# Altium schematic sheet POWER_12OUT_CH2 不焊接.SchDoc
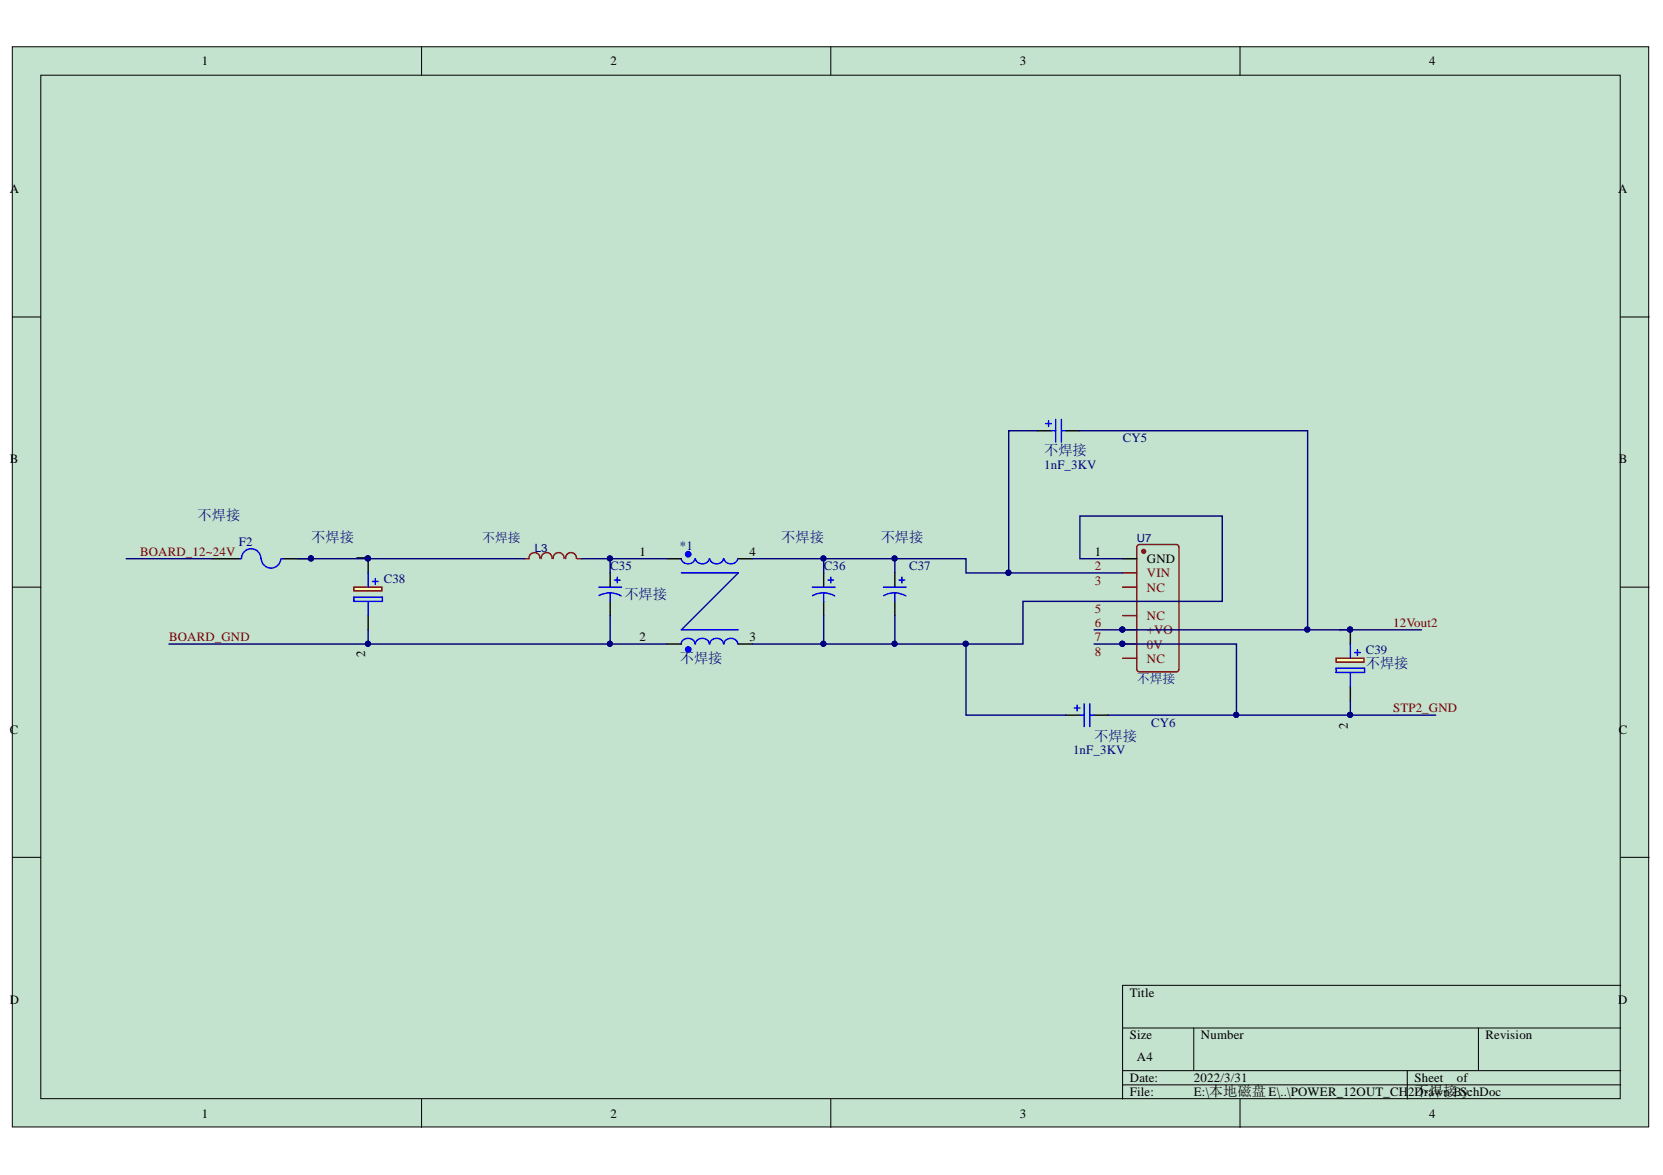
[diagram p0: POWER_12OUT_CH2 不焊接.SchDoc]

## Parts
*1  不焊接  [FL2D-XX-XXX]
C35  不焊接  [C1210_N]
C36  不焊接  [C1210_N]
C37  不焊接  [EEE0JA152UAP]
C38  不焊接  [CAP12.5*25]
C39  不焊接  [CAPPRD200W55D500H1200]
CY5  不焊接  value 1nF_3KV  [CAP1808]
CY6  不焊接  value 1nF_3KV  [CAP1808]
F2  不焊接  [1206_FUSE]
L3  不焊接  [IND-SMD_L5.2-W5.2_MS0530-XX]
U7  不焊接  [PWRM-TH_UWFXXXXS-3WR3]

## Nets
12VOUT2: C39.1 CY5.2 U7.6(+VO)
BOARD_12~24V: F2.1
BOARD_GND: *1.2 C35.2 C38.2
STP2_GND: C39.2 CY6.2 U7.7(0V)
Net*1_1: *1.1 C35.1 L3.2
Net*1_3: *1.3 C36.2 C37.2 CY6.1 U7.1(GND)
Net*1_4: *1.4 C36.1 C37.1 CY5.1 U7.2(VIN)
NetC38_1: C38.1 F2.2 L3.1

Not connected: U7 3(NC) 5(NC) 8(NC)

## Library data of the parts
*1 = FL2D: Component Kind: Standard; Library Name: Schlib1.SchLib
C35-C37 = Cap Pol2: Polarized Capacitor (Axial); Value: 10uF; Component Kind: Standard; Library Name: Miscellaneous Devices.IntLib; Published: 8-Jun-2000; Revision: July-2002: Re-released for DXP Platform.; Signal Integrity: Cap Pol; Simulation: CAP; Publisher: Altium Limited
C38 = ECA-1HHG102: Capacitor Polarised; Manufacturer_Name: Panasonic; Component Kind: Standard; Datasheet Link: https://componentsearchengine.com//ECA-1HHG102.pdf; Height: 27mm; Manufacturer_Part_Number: ECA-1HHG102; Mouser2 Part Number: 667-ECA-1HHG102; Mouser2 Price/Stock: https://www.mouser.com/Search/Refine.aspx?Keyword=667-ECA-1HHG102
C39 = ECA-1HHG220I: Capacitor Polarised; Manufacturer_Name: Panasonic; Component Kind: Standard; Datasheet Link: http://industrial.panasonic.com/cdbs/www-data/pdf/RDF0000/ABA0000C1215.pdf; Height: 12mm; Manufacturer_Part_Number: ECA-1HHG220I
CY5 CY6 = Cap Pol2: Polarized Capacitor (Axial); Component Kind: Standard; LatestRevisionDate: 17-Jul-2002; LatestRevisionNote: Re-released for DXP Platform.; Library Name: Miscellaneous Devices.IntLib; PackageDescription: Polarized Capacitor; 2 Leads; PackageReference: POLAR0.8; Published: 8-Jun-2000; Signal Integrity: Cap Pol; Simulation: CAP; Publisher: Altium Limited
F2 = Fuse 2: Fuse; Component Kind: Standard; LatestRevisionDate: 17-Jul-2002; LatestRevisionNote: Re-released for DXP Platform.; PackageReference: PIN-W2/E2.8; Published: 8-Jun-2000; Simulation: FUSE; Library Name: jinrylib.IntLib; Publisher: Altium Limited
L3 = 4.7uH: BOM_Manufacturer: COILMX; BOM_Manufacturer Part: MS0530-4R7M; Contributor: LCSC; nameAlias: Inductance; BOM_JLCPCB Part Class: Extended Part; BOM_Supplier: LCSC; BOM_Supplier Part: C380165; Component Kind: Standard; Library Name: Schlib1.SchLib; spicePre: L; spiceSymbolName: MS0530-4R7M
U7 = UWF1212S-3WR3: BOM_Manufacturer: MORNSUN(金升阳); Contributor: LCSC; BOM_JLCPCB Part Class: Extended Part; BOM_Manufacturer Part: UWF1212S-3WR3; BOM_Supplier: LCSC; BOM_Supplier Part: C2684865; Component Kind: Standard; Library Name: Schlib1.SchLib; spicePre: U; spiceSymbolName: UWF1212S-3WR3
